# Altium schematic sheet MCU.SchDoc
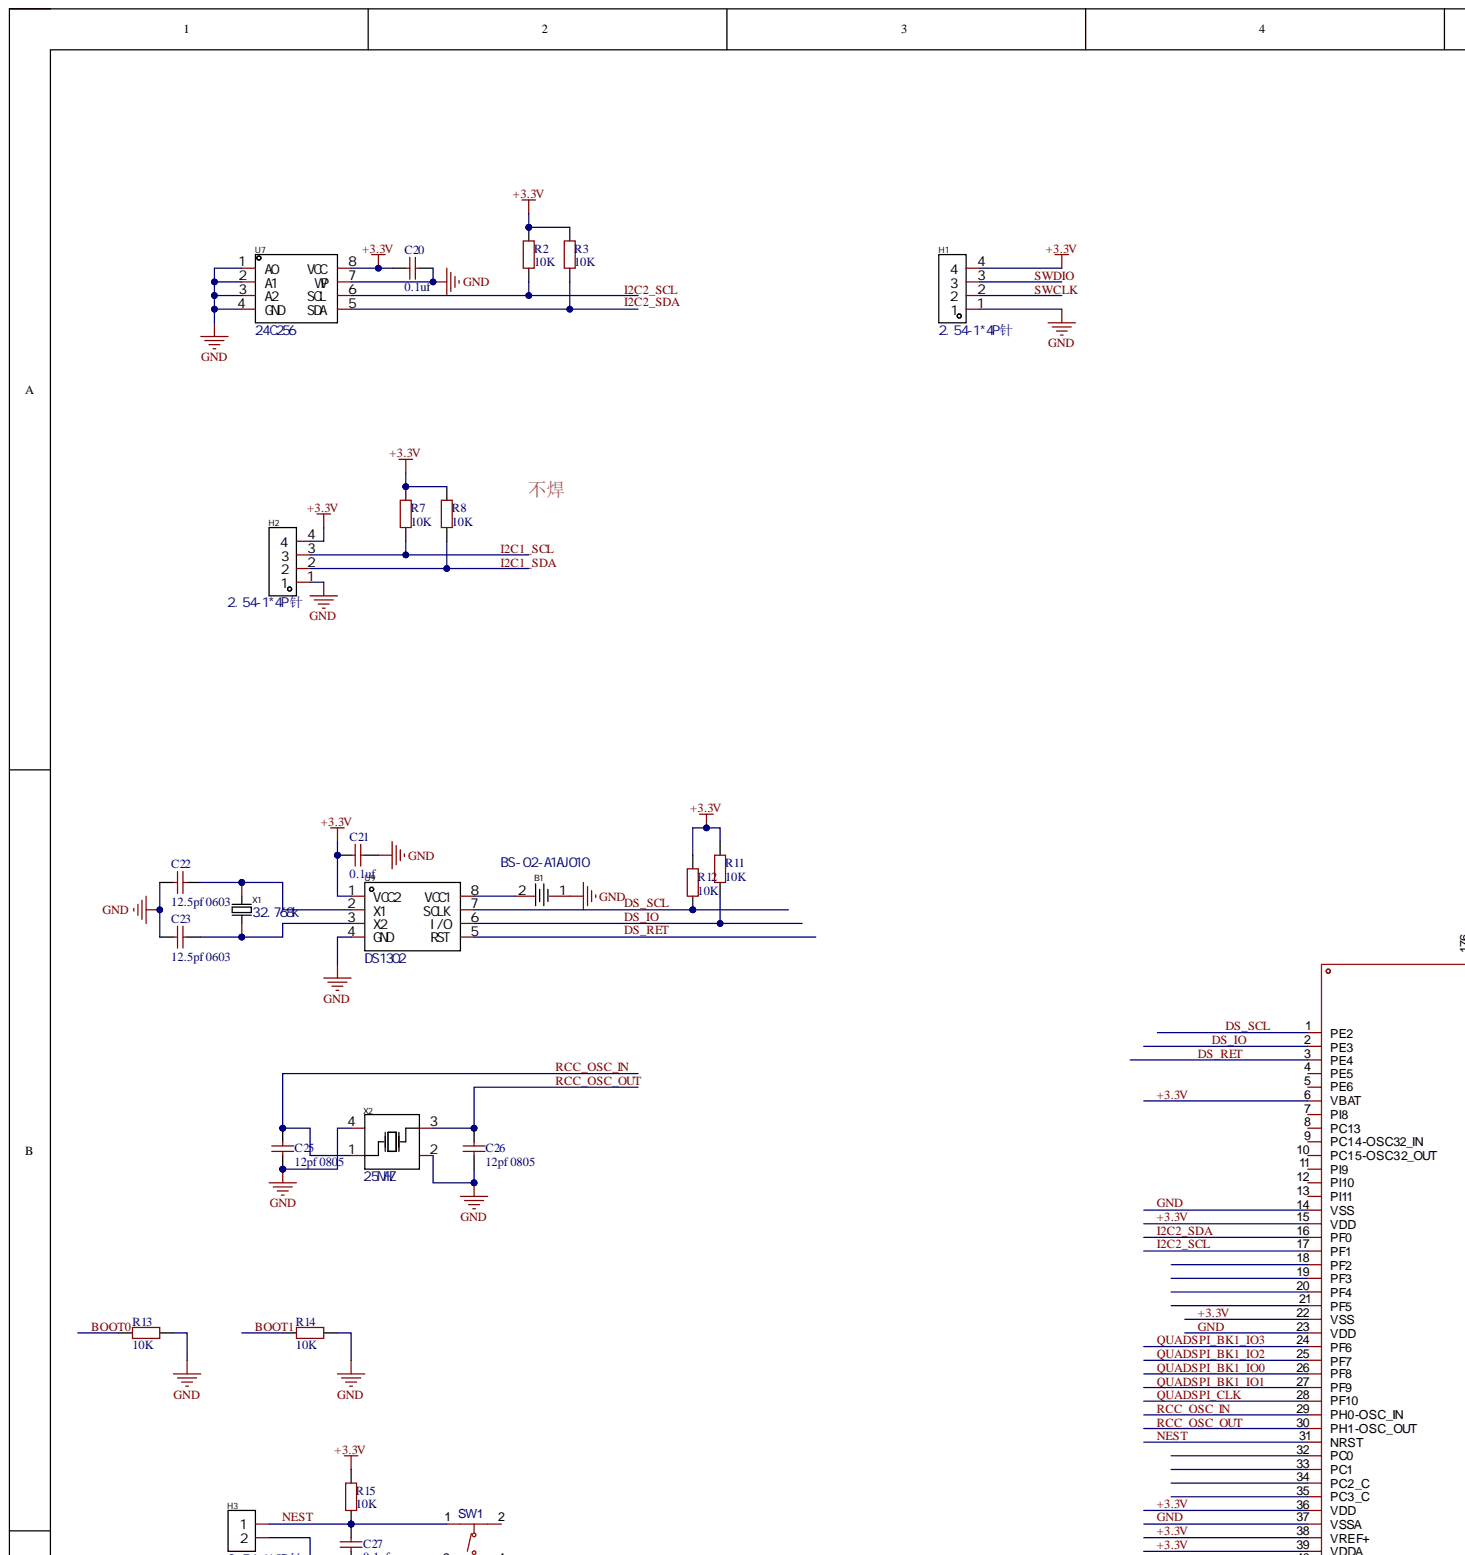
[diagram p0: MCU.SchDoc, top left]
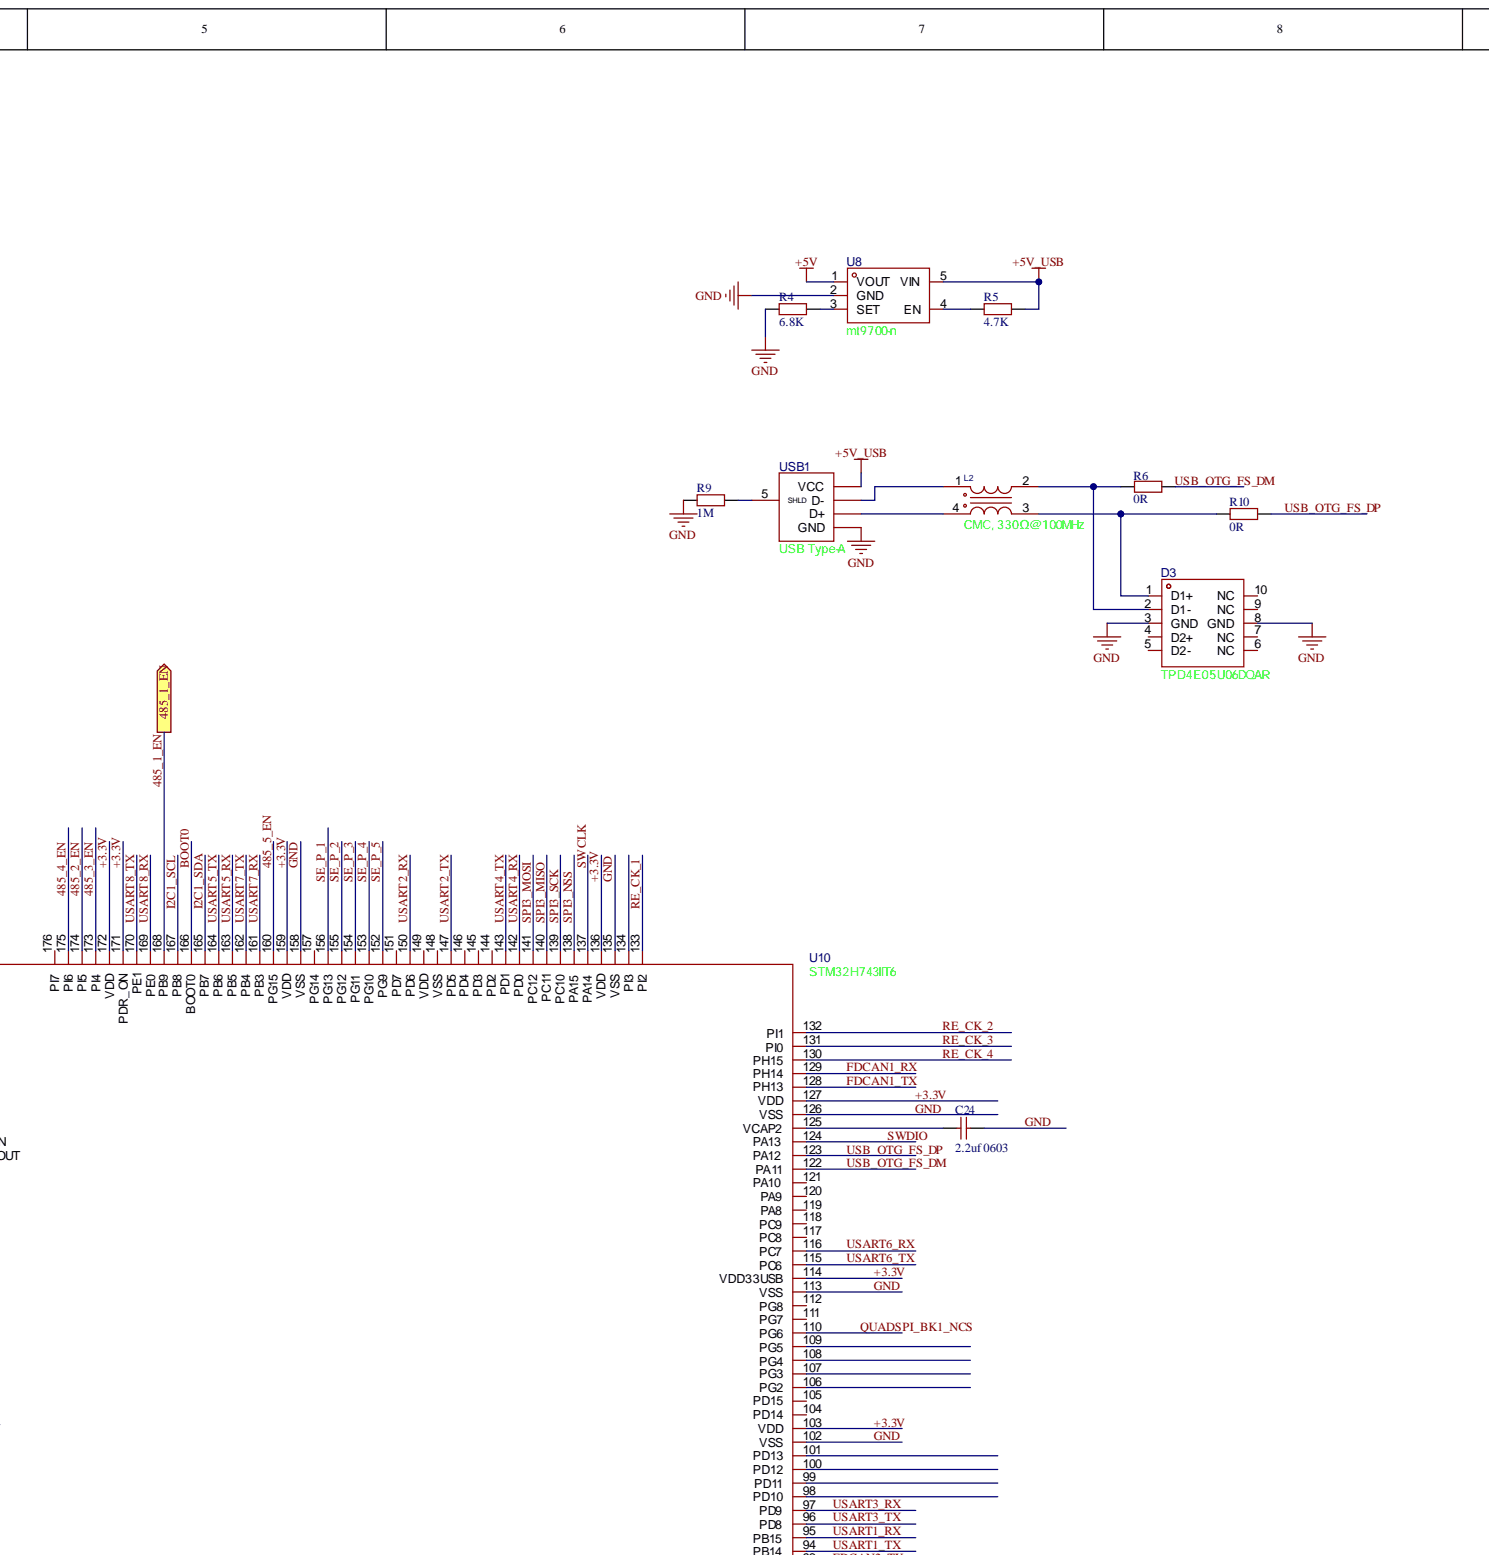
[diagram p1: MCU.SchDoc, top centre]
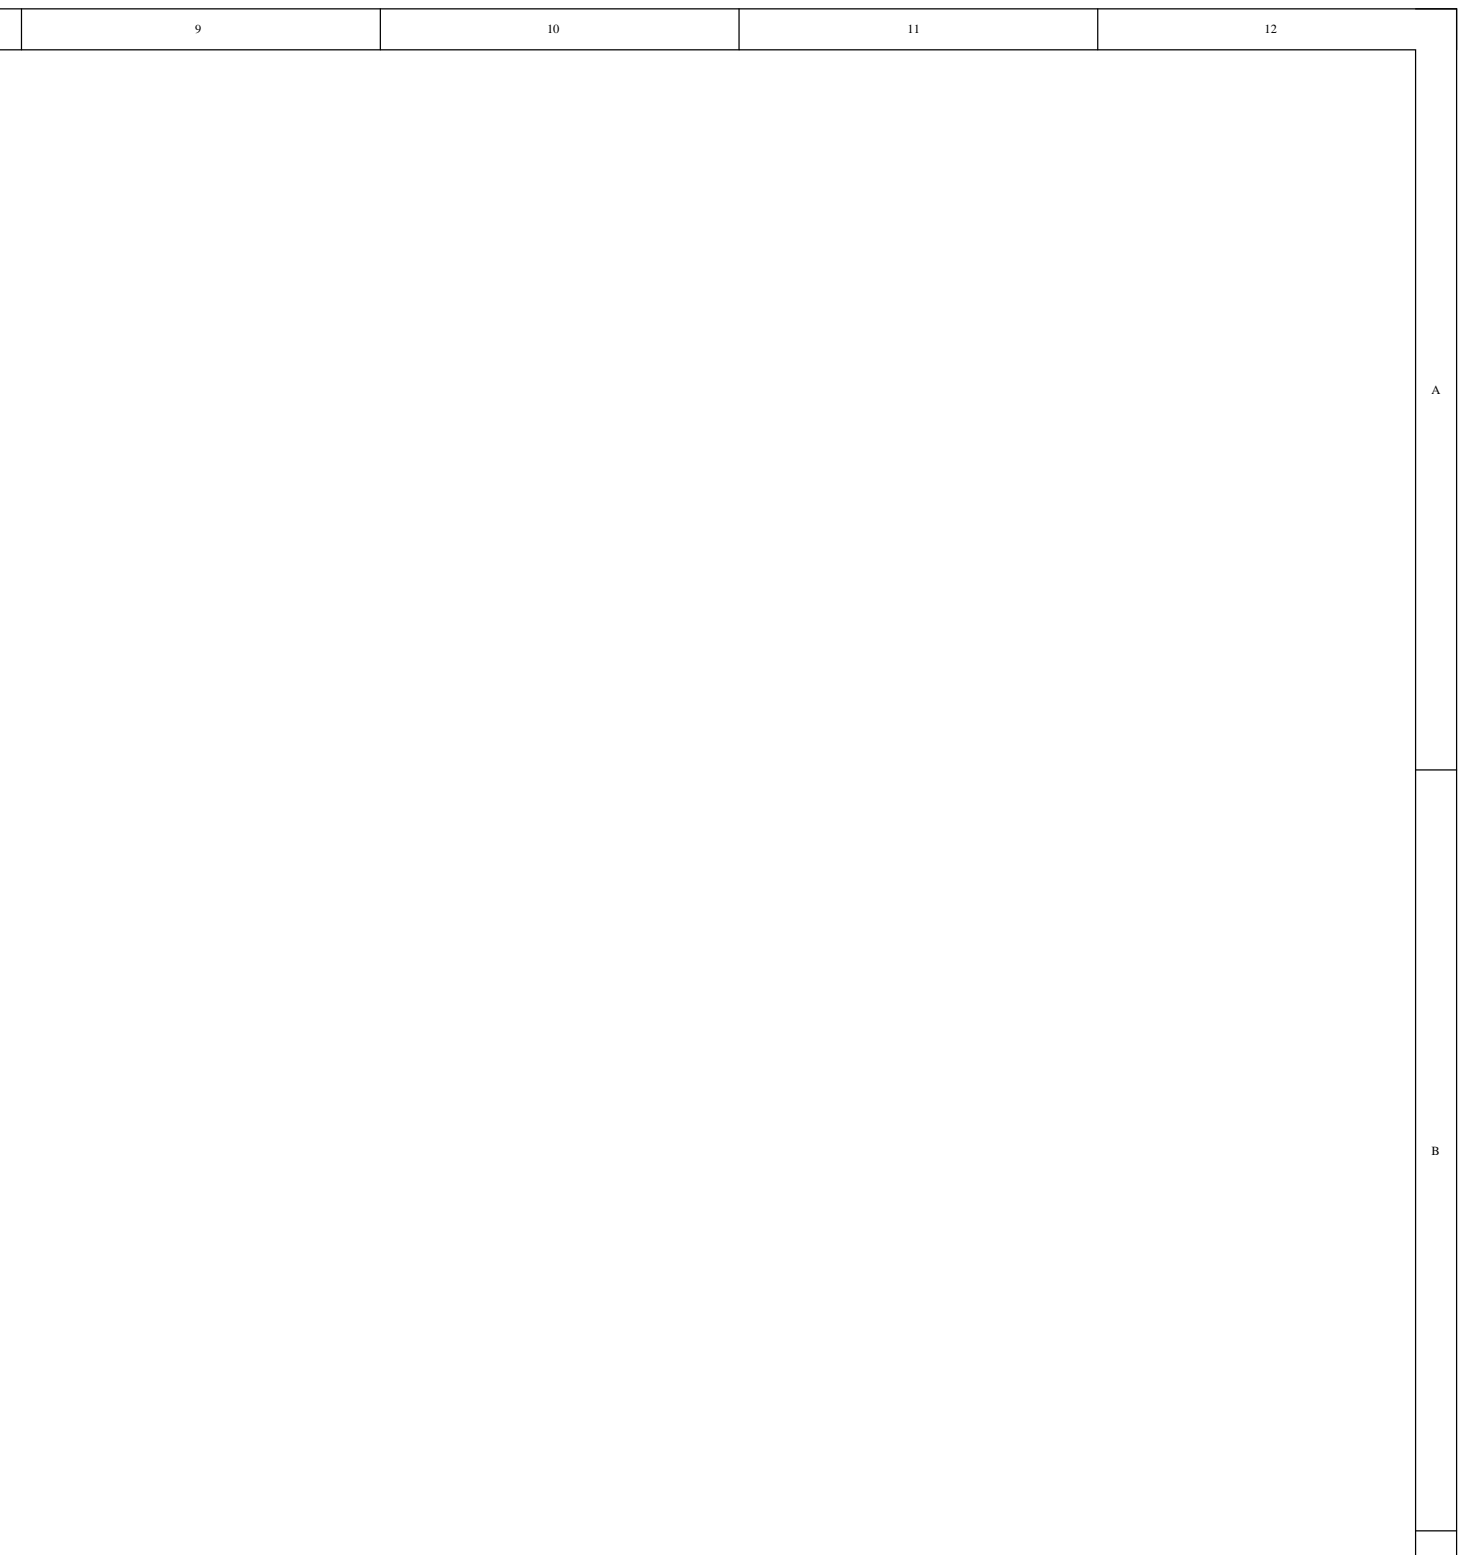
[diagram p2: MCU.SchDoc, top right]
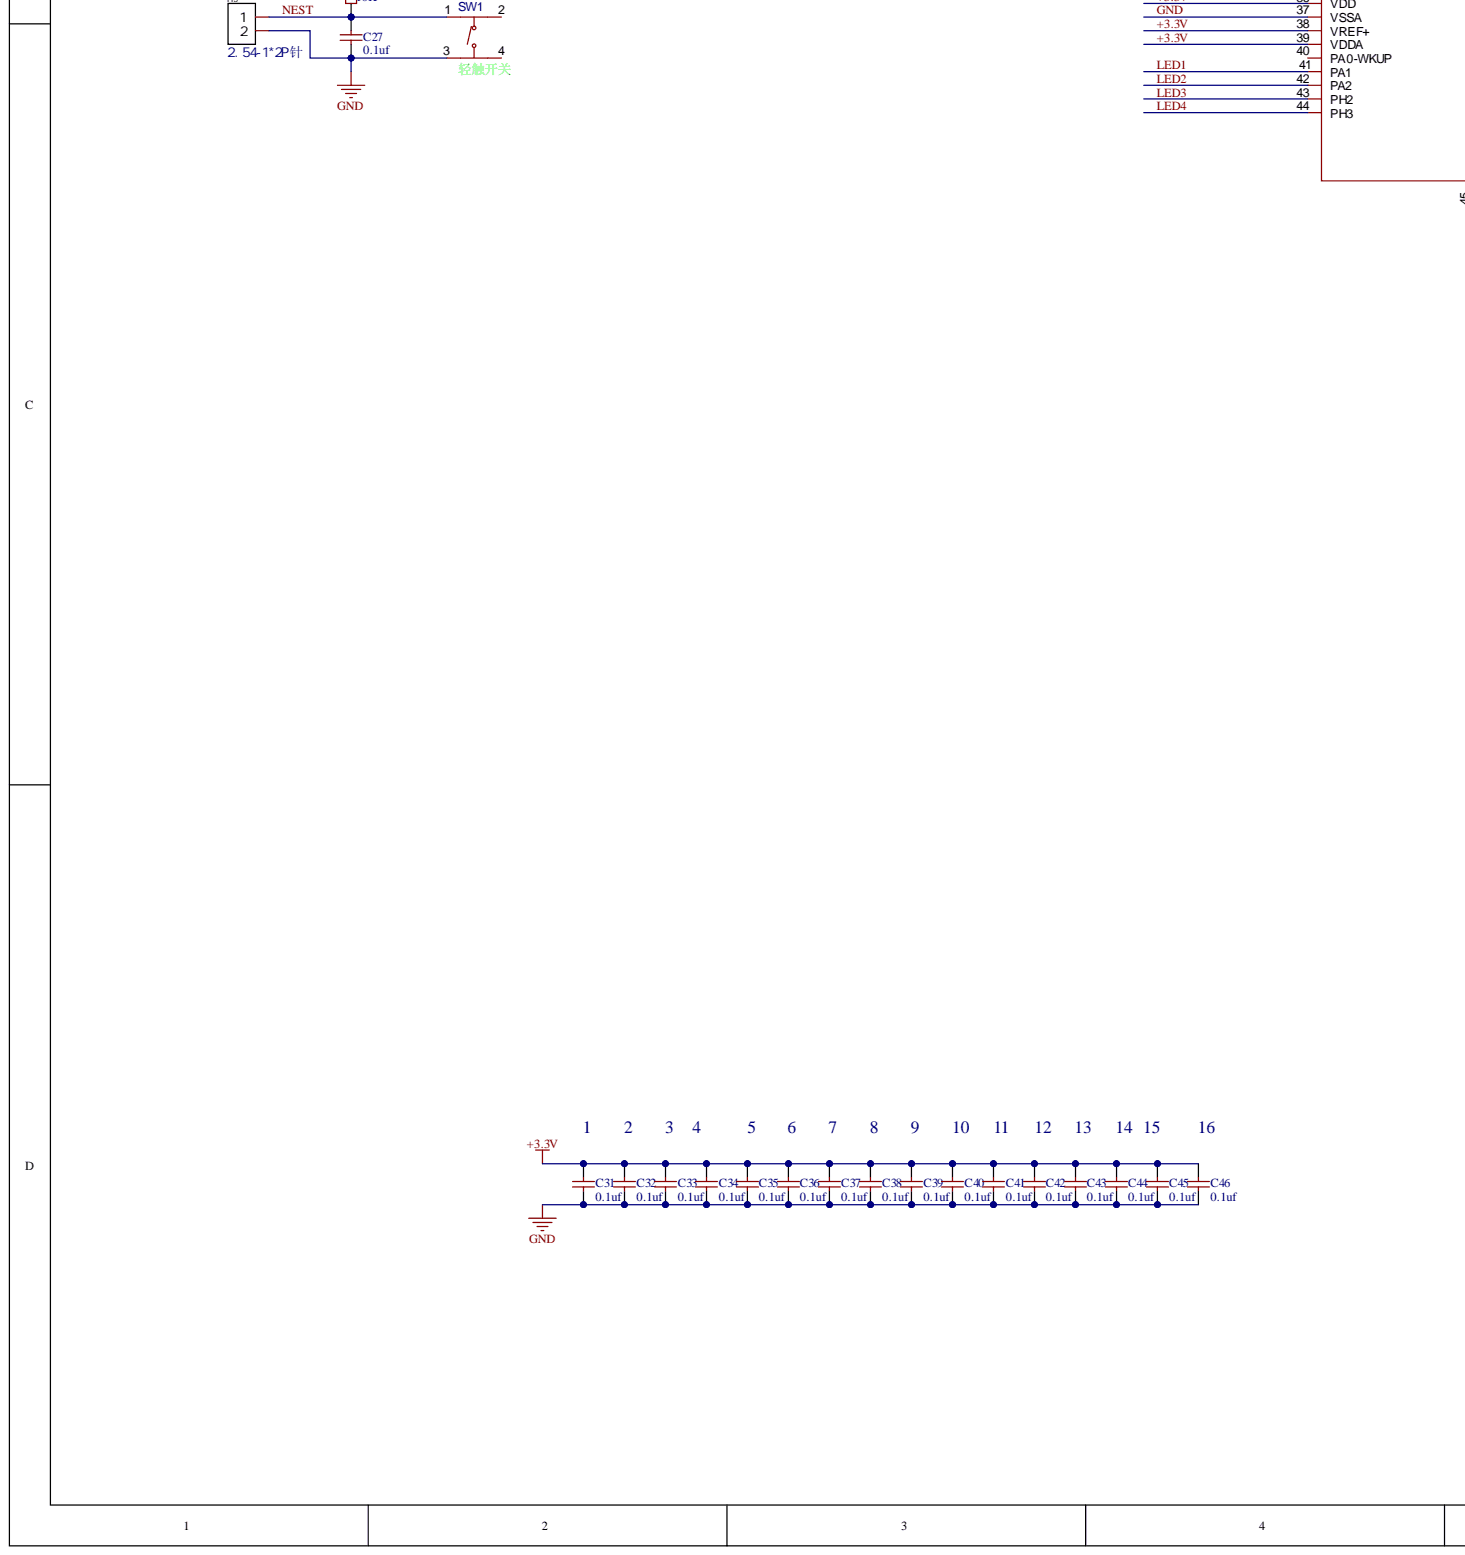
[diagram p3: MCU.SchDoc, bottom left]
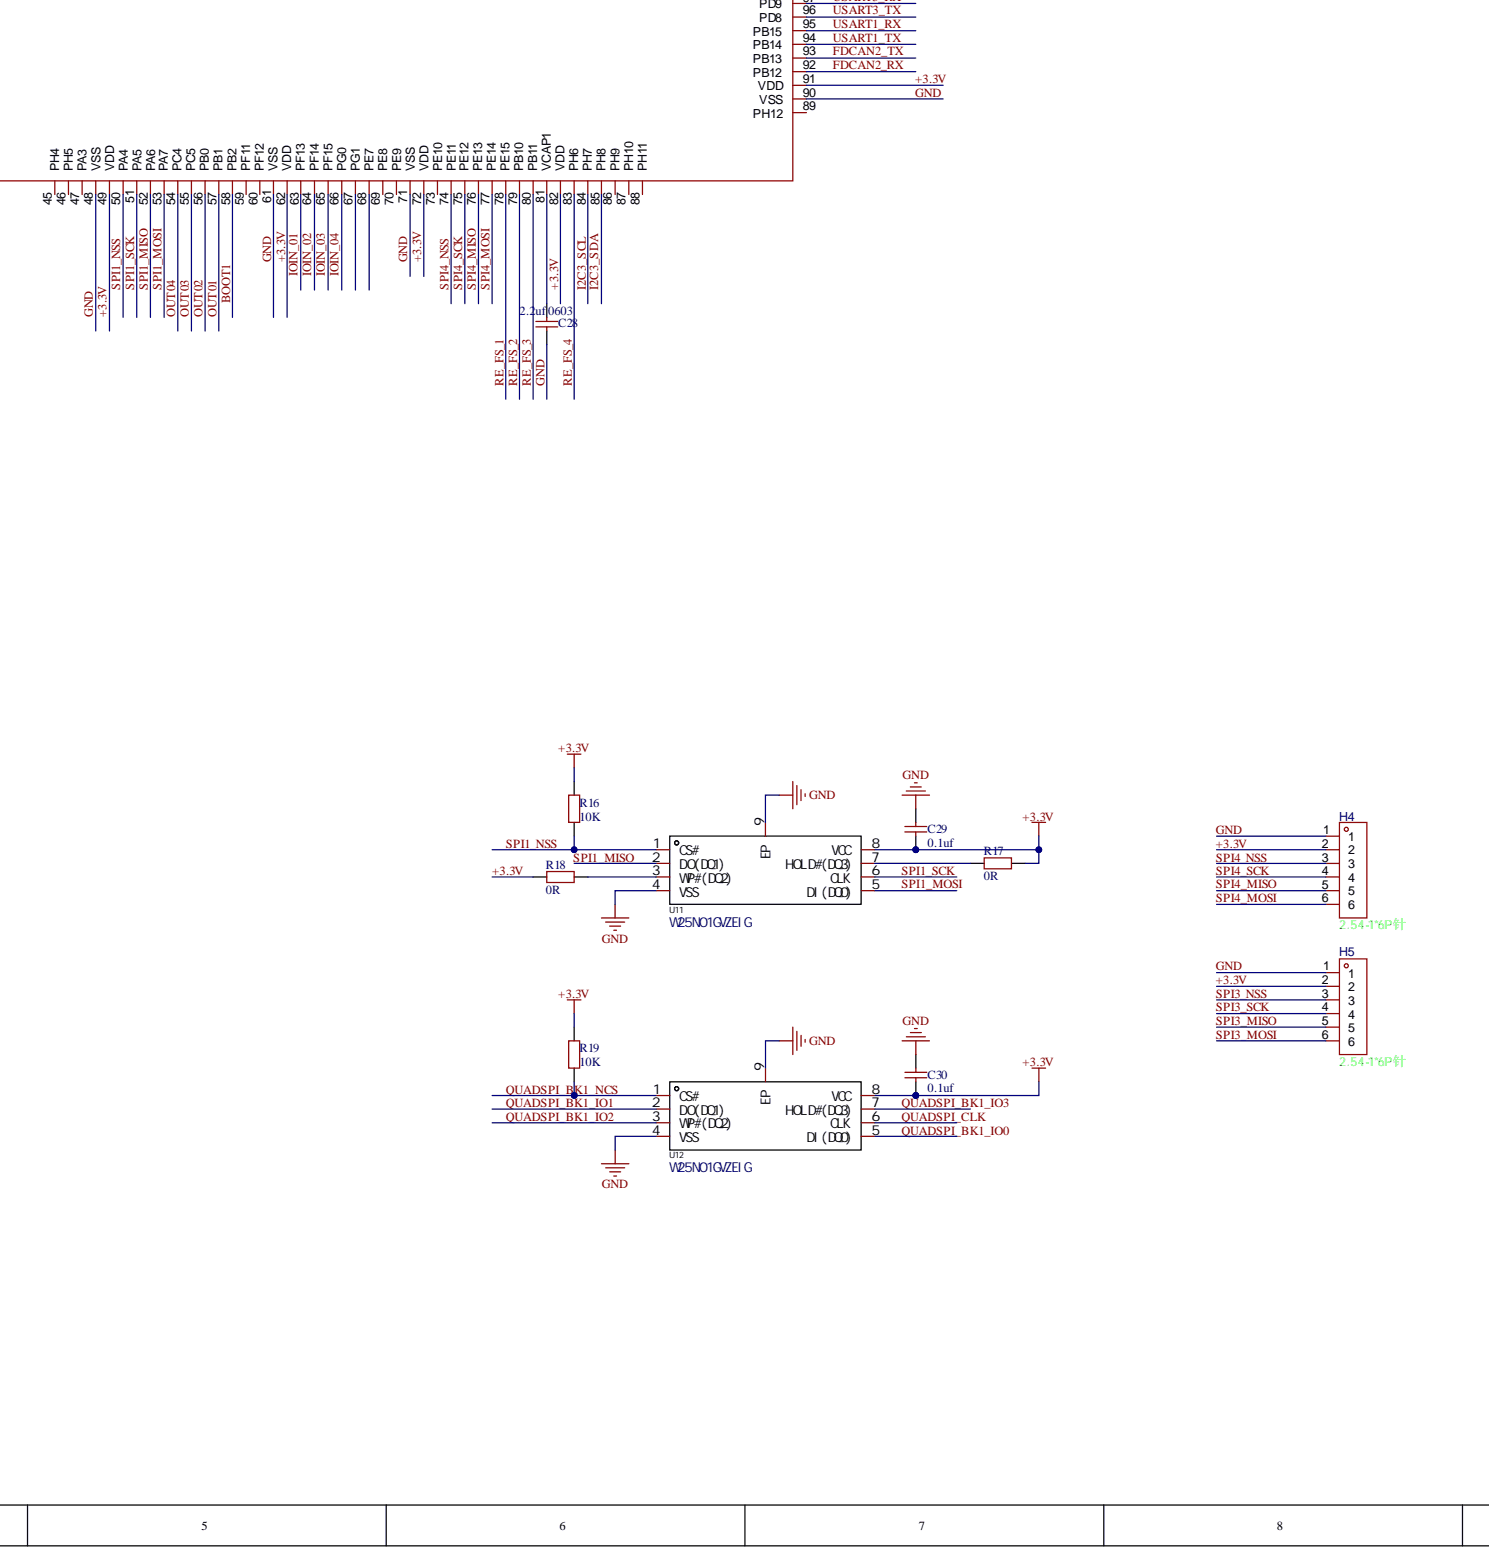
[diagram p4: MCU.SchDoc, bottom centre]
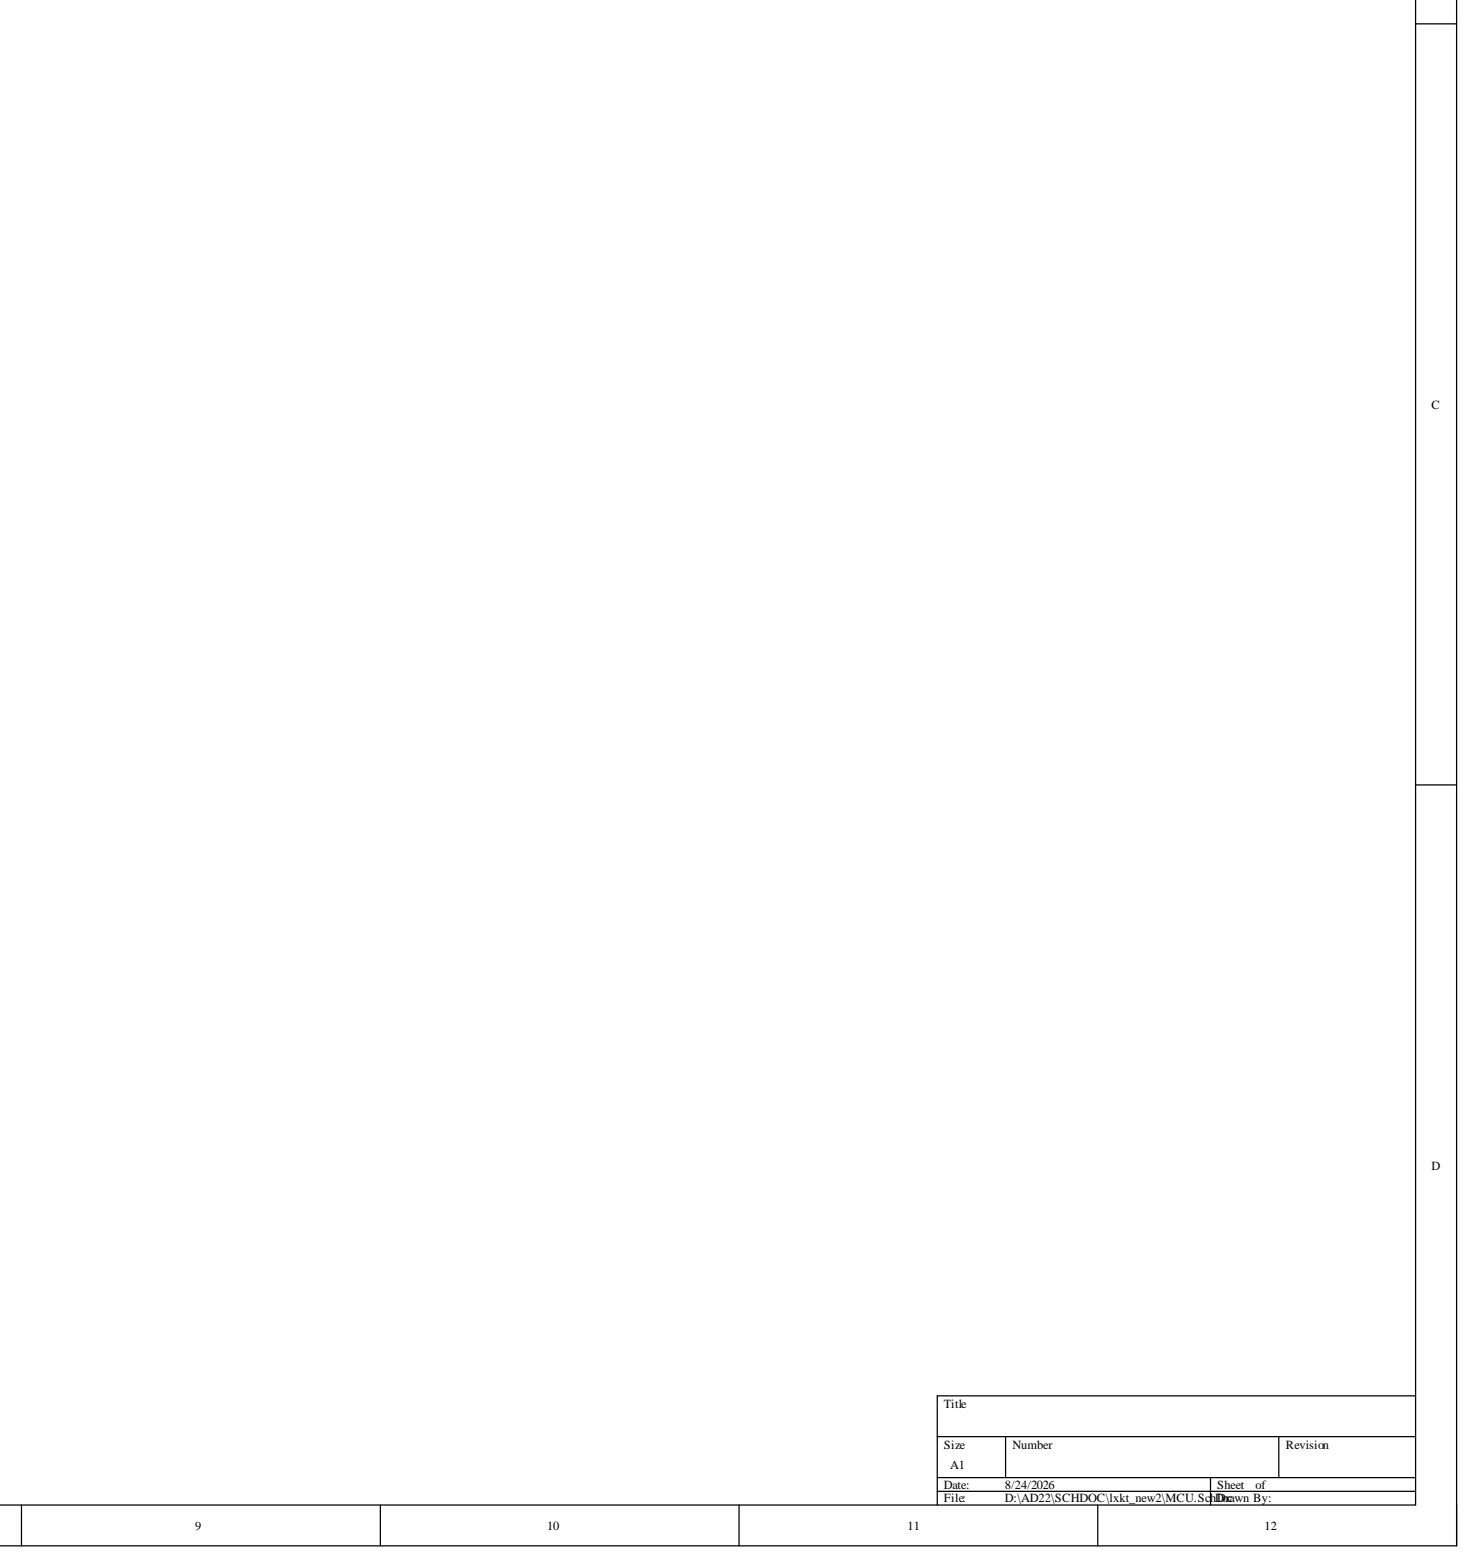
[diagram p5: MCU.SchDoc, bottom right]

## Parts
B1  BS-02-A1AJ010  [BAT-TH_BS-02-A1AJ010]
C20  0.1uf  [0805_C]
C21  0.1uf  [0805_C]
C22  12.5pf 0603  Package: 0603  [0805_C]
C23  12.5pf 0603  Package: 0603  [0805_C]
C24  2.2uf 0603  Package: 0603  [0805_C]
C25  12pf 0805  [0805_C]
C26  12pf 0805  [0805_C]
C27  0.1uf  [0805_C]
C28  2.2uf 0603  Package: 0603  [0805_C]
C29  0.1uf  [0805_C]
C30  0.1uf  [0805_C]
C31  0.1uf  [0805_C]
C32  0.1uf  [0805_C]
C33  0.1uf  [0805_C]
C34  0.1uf  [0805_C]
C35  0.1uf  [0805_C]
C36  0.1uf  [0805_C]
C37  0.1uf  [0805_C]
C38  0.1uf  [0805_C]
C39  0.1uf  [0805_C]
C40  0.1uf  [0805_C]
C41  0.1uf  [0805_C]
C42  0.1uf  [0805_C]
C43  0.1uf  [0805_C]
C44  0.1uf  [0805_C]
C45  0.1uf  [0805_C]
C46  0.1uf  [0805_C]
D3  TPD4E05U06DQAR  [USON-10_L2.5-W1.0-P0.50-BL]
H1  2.54-1*4P针  [HDR-TH_4P-P2.54-V-M]
H2  2.54-1*4P针  [HDR-TH_4P-P2.54-V-M]
H3  2.54-1*2P针  [HDR-TH_2P-P2.54-V-M]
H4  2.54-1*6P针  [HDR-TH_6P-P2.54-V-M]
H5  2.54-1*6P针  [HDR-TH_6P-P2.54-V-M]
L2  CMC, 330Ω@100MHz  Impedance @ Frequency: 330Ω@100MHz  LCSC Part Name: 2 330Ω@100MHz  [FILTER-SMD_4P-L3.2-W1.6-BL_APCW3216]
R2  10K  [0805_R]
R3  10K  [0805_R]
R4  6.8K  [0805_R]
R5  4.7K  [0805_R]
R6  0R  [0805_R]
R7  10K  [0805_R]
R8  10K  [0805_R]
R9  1M  [0805_R]
R10  0R  [0805_R]
R11  10K  [0805_R]
R12  10K  [0805_R]
R13  10K  [0805_R]
R14  10K  [0805_R]
R15  10K  [0805_R]
R16  10K  [0805_R]
R17  0R  [0805_R]
R18  0R  [0805_R]
R19  10K  [0805_R]
SW1  轻触开关  [SW-SMD_4P-L5.1-W5.1-P3.70-LS6.5-TL_H1.5]
U7  24C256  [SOIC-8_L5.0-W4.0-P1.27-LS6.0-BL]
U8  mt9700-n  [SOT-23-5_L2.9-W1.6-P0.95-LS2.9-BL]
U9  DS1302  [SOP-8_L4.9-W3.9-P1.27-LS6.0-BL]
U10  STM32H743IIT6  [LQFP-176_L24.0-W24.0-P0.50-LS26.0-BL]
U11  W25N01GVZEIG  [WSON-8_L8.0-W6.10-P1.27-BL-EP]
U12  W25N01GVZEIG  [WSON-8_L8.0-W6.10-P1.27-BL-EP]
USB1  USB Type-A  Connector Type: Type-A  [USB-A-TH_AF-WJDG]
X1  32.768k  [OSC-TH_BD3.1-P0.8-D0.3]
X2  25MHZ  [SMD3225-4P]

## Ports
485_1_EN

## Nets
+5V: U8.1(VOUT)
+5V_USB: R5.1 U8.5(VIN) USB1.1(VCC)
485_1_EN: U10.168(PB9) ; port 485_1_EN
485_2_EN: U10.174(PI5)
485_3_EN: U10.173(PI4)
485_4_EN: U10.175(PI6)
485_5_EN: U10.160(PG15)
+3.3V: C20.2 C21.2 C29.1 C30.1 C31.2 C32.2 C33.2 C34.2 C35.2 C36.2 C37.2 C38.2 C39.2 C40.2 C41.2 C42.2 C43.2 C44.2 C45.2 C46.2 H1.4 H2.4 H4.2 H5.2 R2.2 R3.2 R7.2 R8.2 R11.2 R12.2 R15.2 R16.2 R17.1 R18.2 R19.2 U7.8(VCC) U9.1(VCC2) U10.6(VBAT) U10.15(VDD) U10.22(VSS) U10.36(VDD) U10.38(VREF+) U10.39(VDDA) U10.49 U10.62(VDD) U10.72(VDD) U10.82(VDD) U10.91(VDD) U10.103(VDD) U10.114(VDD33USB) U10.127(VDD) U10.136(VDD) U10.159(VDD) U10.171(PDR_ON) U10.172 U11.8(VCC) U12.8(VCC)
BOOT0: R13.1 U10.166(BOOT0)
BOOT1: R14.1 U10.58(PB2)
DS_IO: R11.1 U9.6(I/O) U10.2(PE3)
DS_RET: U9.5(RST) U10.3(PE4)
DS_SCL: R12.1 U9.7(SCLK) U10.1(PE2)
FDCAN1_RX: U10.129(PH14)
FDCAN1_TX: U10.128(PH13)
FDCAN2_RX: U10.92(PB12)
FDCAN2_TX: U10.93(PB13)
GND: B1.1 C20.1 C21.1 C22.1 C23.1 C24.1 C25.1 C26.1 C27.1 C28.1 C29.2 C30.2 C31.1 C32.1 C33.1 C34.1 C35.1 C36.1 C37.1 C38.1 C39.1 C40.1 C41.1 C42.1 C43.1 C44.1 C45.1 C46.1 D3.3(GND) D3.8(GND) H1.1 H2.1 H3.2 H4.1 H5.1 R4.2 R9.2 R13.2 R14.2 SW1.3 U7.1(A0) U7.2(A1) U7.3(A2) U7.4(GND) U7.7(WP) U8.2(GND) U9.4(GND) U10.14(VSS) U10.23(VDD) U10.37(VSSA) U10.48(VSS) U10.61(VSS) U10.71(VSS) U10.90(VSS) U10.102(VSS) U10.113(VSS) U10.126(VSS) U10.135(VSS) U10.158(VSS) U11.4(VSS) U11.9(EP) U12.4(VSS) U12.9(EP) USB1.4(GND) X2.2 X2.4
I2C1_SCL: H2.3 R7.1 U10.167(PB8)
I2C1_SDA: H2.2 R8.1 U10.165(PB7)
I2C2_SCL: R2.1 U7.6(SCL) U10.17(PF1)
I2C2_SDA: R3.1 U7.5(SDA) U10.16(PF0)
I2C3_SCL: U10.84(PH7)
I2C3_SDA: U10.85(PH8)
IOIN_01: U10.63(PF13)
IOIN_02: U10.64(PF14)
IOIN_03: U10.65
IOIN_04: U10.66(PG0)
LED1: U10.41(PA1)
LED2: U10.42(PA2)
LED3: U10.43(PH2)
LED4: U10.44(PH3)
NEST: C27.2 H3.1 R15.1 SW1.1 U10.31(NRST)
OUT01: U10.57(PB1)
OUT02: U10.56(PB0)
OUT03: U10.55(PC5)
OUT04: U10.54(PC4)
QUADSPI_BK1_IO0: U10.26(PF8) U12.5(DI(DQ0))
QUADSPI_BK1_IO1: U10.27(PF9) U12.2(DO(DQ1))
QUADSPI_BK1_IO2: U10.25(PF7) U12.3(WP#(DQ2))
QUADSPI_BK1_IO3: U10.24(PF6) U12.7(HOLD#(DQ3))
QUADSPI_BK1_NCS: R19.1 U10.110(PG6) U12.1(CS#)
QUADSPI_CLK: U10.28(PF10) U12.6(CLK)
RCC_OSC_IN: C25.2 U10.29(PH0-OSC_IN) X2.1
RCC_OSC_OUT: C26.2 U10.30(PH1-OSC_OUT) X2.3
RE_CK_1: U10.133(PI2)
RE_CK_2: U10.132(PI1)
RE_CK_3: U10.131(PI0)
RE_CK_4: U10.130(PH15)
RE_FS_1: U10.78(PE15)
RE_FS_2: U10.79(PB10)
RE_FS_3: U10.80(PB11)
RE_FS_4: U10.83(PH6)
SE_P_1: U10.156
SE_P_2: U10.155(PG12)
SE_P_3: U10.154(PG11)
SE_P_4: U10.153(PG10)
SE_P_5: U10.152(PG9)
SPI1_MISO: U10.52(PA6) U11.2(DO(DQ1))
SPI1_MOSI: U10.53(PA7) U11.5(DI(DQ0))
SPI1_NSS: R16.1 U10.50(PA4) U11.1(CS#)
SPI1_SCK: U10.51(PA5) U11.6(CLK)
SPI3_MISO: H5.5 U10.140(PC11)
SPI3_MOSI: H5.6 U10.141(PC12)
SPI3_NSS: H5.3 U10.138(PA15)
SPI3_SCK: H5.4 U10.139(PC10)
SPI4_MISO: H4.5 U10.76(PE13)
SPI4_MOSI: H4.6 U10.77(PE14)
SPI4_NSS: H4.3 U10.74(PE11)
SPI4_SCK: H4.4 U10.75(PE12)
SWCLK: H1.2 U10.137(PA14)
SWDIO: H1.3 U10.124(PA13)
USART1_RX: U10.95(PB15)
USART1_TX: U10.94(PB14)
USART2_RX: U10.150(PD6)
USART2_TX: U10.147(PD5)
USART3_RX: U10.97(PD9)
USART3_TX: U10.96(PD8)
USART4_RX: U10.142(PD0)
USART4_TX: U10.143(PD1)
USART5_RX: U10.163(PB5)
USART5_TX: U10.164(PB6)
USART6_RX: U10.116(PC7)
USART6_TX: U10.115(PC6)
USART7_RX: U10.161(PB3)
USART7_TX: U10.162(PB4)
USART8_RX: U10.169(PE0)
USART8_TX: U10.170(PE1)
USB_OTG_FS_DM: R6.1 U10.122(PA11)
USB_OTG_FS_DP: R10.1 U10.123(PA12)
NetB1_2: B1.2 U9.8(VCC1)
NetC22_2: C22.2 U9.2(X1) X1.1
NetC23_2: C23.2 U9.3(X2) X1.2
NetC24_2: C24.2 U10.125(VCAP2)
NetC28_2: C28.2 U10.81(VCAP1)
NetD3_1: D3.1(D1+) L2.3 R10.2
NetD3_2: D3.2(D1-) L2.2 R6.2
NetL2_1: L2.1 USB1.2(D-)
NetL2_4: L2.4 USB1.3(D+)
NetR4_1: R4.1 U8.3(SET)
NetR5_2: R5.2 U8.4(EN)
NetR9_1: R9.1 USB1.5(SHLD)
NetR17_2: R17.2 U11.7(HOLD#(DQ3))
NetR18_1: R18.1 U11.3(WP#(DQ2))

Not connected: D3 4(D2+) 5(D2-) 6(NC) 7(NC) 9(NC) 10(NC); SW1 2 4; U10 4(PE5) 5(PE6) 7(PI8) 8(PC13) 9(PC14-OSC32_IN) 10(PC15-OSC32_OUT) 11(PI9) 12(PI10) 13(PI11) 18(PF2) 19(PF3) 20(PF4) 21(PF5) 32(PC0) 33(PC1) 34(PC2_C) 35(PC3_C) 40(PA0-WKUP) 45(PH4) 46(PH5) 47(PA3) 59(PF11) 60(PF12) 67(PG1) 68(PE7) 69(PE8) 70(PE9) 73(PE10) 86(PH9) 87(PH10) 88(PH11) 89(PH12) 98(PD10) 99(PD11) 100(PD12) 101(PD13) 104(PD14) 105(PD15) 106(PG2) 107(PG3) 108(PG4) 109(PG5) 111(PG7) 112(PG8) 117(PC8) 118(PC9) 119(PA8) 120(PA9) 121(PA10) 134(PI3) 144(PD2) 145(PD3) 146(PD4) 148(VSS) 149(VDD) 151(PD7) 157(PG14) 176(PI7)

## Library data of the parts
B1 = BS-02-A1AJ010: 电池座; Manufacturer: MYOUNG(美阳); Add into BOM: yes; Battery Type: CR2032; Connector Type: 电池底座; Component Kind: Standard; Convert to PCB: yes; Datasheet: https://atta.szlcsc.com/upload/public/pdf/source/20221102/8F00F2B23A2A3C447A59C327BBA6C743.pdf; Device: BS-02-A1AJ010; JLCPCB Part Class: Extended Part; LCSC Part Name: 电池底座 适用电池:CR2032; Manufacturer Part: BS-02-A1AJ010; Operating Temperature Range: -25℃~+85℃; Supplier: LCSC; Supplier Footprint: 插件; Supplier Part: C5239862; Symbol: BS-02-A1AJ010; Library Name: lxkt.IntLib
C20-C46 = C 0603 1.0pF (010) 0.25pF 50V: 贴片电容; Component Kind: Standard; ComponentLink1Description: 供应商链接; ComponentLink1URL: http://www.szlcsc.com/product/details_24709.html; Library Name: BasicComponents2205.IntLib; Supplier: LC; SuppliersPartNumber: C23969
  C20 C21 C25-C27 C29-C46: Package: 0603
D3 = TPD4E05U06DQAR: 极性:单向;反向截止电压(Vrwm):5.5V;钳位电压:14V;峰值脉冲电流(Ipp):2.5A@8/20us;峰值脉冲功率(Ppp):40W@8/20us;击穿电压:6.5V;; Manufacturer: TI(德州仪器); AddInBom: yes; Clamping Voltage: 14V; Junction Capacitance: 0.5pF; level of protection: IEC 61000-4-4；IEC 61000-4-5；IEC 61000-4-2; ModelId: ab22a3507c584f4790945dcc3c1a797e; ModelName: USON-10_L2.5-W1.0-H0.6-P0.50; ModelTransform: 40.1574,98.425,0,90,0,0,0.001,0,0; Number of Channels: 四路; Polarity: 单向; Reverse Leakage Current (Ir): 10nA; Reverse Stand-Off Voltage (Vrwm): 5.5V; Symbol: TPD4E05U06DQAR_C138714; Type: ESD; Voltage - Breakdown: 6.5V; Component Kind: Standard; ConvertToPcb: yes; Datasheet: https://www.ti.com/cn/lit/gpn/tpd4e05u06; FootprintName: USON-10_L2.5-W1.0-P0.50-BL; JLCPCB Part Class: Extended Part; LCSC Part Name: 单向TVS 5.5V截止 峰值脉冲电流：2.5A@8/20us; ManufacturerPart: TPD4E05U06DQAR; Operating Temperature: -40℃~+125℃; Peak Pulse Current (Ipp): 2.5A@8/20us; Peak Pulse Power Dissipation (Ppp): 40W@8/20us; Supplier: LCSC; Supplier Footprint: USON-10(1x2.5); SupplierPart: C138714; Library Name: WXcharge0210.IntLib
H1 H2 = 2.54-1*4P针: 排针; Manufacturer: XFCN(兴飞); Add into BOM: yes; Circluar Pins/Square Pins: 方针; Current Rating (Max): 3A; Device: PZ254V-11-04P; Pin Structure: 1x4P; Pitch: 2.54mm; Symbol: PZ254V-11-04P_C2691448; Voltage Rating (Max): 250V; Color: 黑色; Component Kind: Standard; Contact Material: -; Convert to PCB: yes; Datasheet: https://atta.szlcsc.com/upload/public/pdf/source/20200309/C492403_F9F19131791D08044AD4F84F8E751A34.pdf; Insulation Height: 2.5mm; JLCPCB Part Class: Extended Part; LCSC Part Name: 2.54mm 1x4P 直插 方针; Length of End Connection Pin: 3mm; Length of Mating Pin: 6mm; Manufacturer Part: PZ254V-11-04P; Mounting Type: 直插; Name: PZ254V-11-04P; Number of Pins: 4; Number of Rows: 单排; Operating Temperature Range: -40℃~+105℃; Row Spacing: -; Supplier: LCSC; Supplier Footprint: 直插,P=2.54mm; Supplier Part: C2691448; Library Name: WXcharge0210.IntLib
H3 = 2.54-1*2P针: 排针; Manufacturer: BOOMELE(博穆精密); Add into BOM: yes; Circluar Pins/Square Pins: 方针; Current Rating (Max): 3A; Device: 2.54-1*3P针; Pin Structure: 1x3P; Pitch: 2.54mm; Symbol: Header-Male-2.54_1x3; Color: 黑色; Component Kind: Standard; Contact Material: -; Contact Plating: -; Convert to PCB: yes; Datasheet: https://atta.szlcsc.com/upload/public/pdf/source/20221018/3E9408392E35E6F57A28985E5F7BF5C9.pdf; Insulation Height: -; JLCPCB Part Class: Extended Part; LCSC Part Name: 2.54mm 1x3P 直插 方针; Length of End Connection Pin: -; Length of Mating Pin: -; Manufacturer Part: 2.54-1*3P针; Mounting Type: 直插; Name: 2.54-1*3P针; Number of Pins: 3; Number of Rows: 单排; Operating Temperature Range: -40℃~+105℃; Row Spacing: -; Supplier: LCSC; Supplier Footprint: 插件,P=2.54mm; Supplier Part: C49257; Voltage Rating (Max): -; Library Name: WXcharge0210.IntLib
H4 H5 = 2.54-1*6P针: 排针; Symbol: PZ254V-11-10P; Component Kind: Standard; Library Name: WXcharge0210.IntLib
L2 = CMC, 330Ω@100MHz: 通道数:2;电感@频率:-;阻抗@频率:330Ω@100MHz;直流电阻(DCR):-;C54123800 C2926979 C41364541; Manufacturer: APV(爱普微); ManufacturerPart: APCW3216-331P2T; AddInBom: yes; Insulation Resistance(IR): 10MΩ; ModelId: b0aebaa3e6e54d54922b08140cb08b41; ModelTransform: 126.7714,63.7794,0,0,0,0,0,0,0; Number of Lines: 2; Voltage Rating - DC: 50V; Component Kind: Standard; ConvertToPcb: yes; Current Rating: -; Datasheet: https://item.szlcsc.com/datasheet/APCW3216-331P2T/57349933.html; DC Resistance(DCR): -; FootprintName: FILTER-SMD_4P-L3.2-W1.6-BL_APCW3216; Inductance @ Frequency: -; JLCPCB Part Class: Extended Part; ModelName: FILTER-SMD_4P-L3.2-W1.6-BL_APCW3216; Operating Temperature: -40℃~+125℃; Supplier: LCSC; Supplier Footprint: SMD-4P,3.2x1.6mm; SupplierPart: C54123800; SymbolName: APCW3216-331P2T; Voltage Rating (AC): -; Voltage Withstand: -; Library Name: WXcharge0210.IntLib
R2-R19 = R 0603 0Ω (0R0) 1%: 贴片电阻; Component Kind: Standard; ComponentLink1Description: 供应商链接; ComponentLink1URL: http://www.szlcsc.com/product/details_21903.html; Library Name: BasicComponents2205.IntLib; Package: 0603; Supplier: LC; SuppliersPartNumber: C21189
SW1 = TS-1187A-B-A-B: 电路结构:单刀单掷;按钮形状:圆形按钮;作用力:1.6N;安装方式:立贴;开关长度:5.1mm;开关宽度:5.1mm;开关高度:1.5mm;带支架:不带支架;触点电流:50mA;额定电压:12V;带灯:无;工作寿命:10万次;C318884; Manufacturer: XKB Connection(中国星坤); Actuator Style: 圆形按钮; Actuator/Cap Color: 金色; AddInBom: yes; Circuit: 单刀单掷; Contact Current: 50mA; Life: 10万次; ModelId: 56992f66d31b474c84a0c3bd0a81f82f; ModelTransform: 255.905,204.76337,0,0,0,0,0,0,0; Operating Force: 1.6N; Switch Height: 1.5mm; Voltage Rating: 12V; with Bracket: 不带支架; With Lamp: 无; Component Kind: Standard; ConvertToPcb: yes; Datasheet: https://item.szlcsc.com/datasheet/TS-1187A-B-A-B/299976.html; FootprintName: SW-SMD_4P-L5.1-W5.1-P3.70-LS6.5-TL_H1.5; JLCPCB Part Class: Basic Part; LCSC Part Name: 5.1*5.1*1.5mm 立贴 轻触开关; Length: 5.1mm; ManufacturerPart: TS-1187A-B-A-B; ModelName: SW-SMD_4P-L5.1-W5.1-P3.70-LS6.5-TL_H1.5; Mounting Type: 立贴; Operating Temperature: -30℃~+85℃; Supplier: LCSC; Supplier Footprint: SMD; SupplierPart: C318884; Symbol: TS-1187A-B-A-B; Width: 5.1mm; Library Name: WXcharge0210.IntLib
U7 = 24C02: EEPROM; Manufacturer: HGSEMI(华冠); Add into BOM: yes; Device: 24C02/TR; Component Kind: Standard; Convert to PCB: yes; Datasheet: https://atta.szlcsc.com/upload/public/pdf/source/20181022/C316692_1A8AF8718EBD0B12F50671BDFB0B8E66.pdf; JLCPCB Part Class: Extended Part; LCSC Part Name: 24C02/TR; Manufacturer Part: 24C02/TR; Name: 24C02/TR; Supplier: LCSC; Supplier Footprint: SOP-8; Supplier Part: C316692; Symbol: 24C02/TR; Library Name: WXcharge0210.IntLib
U8 = MT9700-N: USB电源,类型:-;通道数:1;输入控制逻辑:高电平有效;工作电压:2.4V~5.5V;最大连续电流:2A;导通电阻:80mΩ;; Manufacturer: 西安航天民芯; AddInBom: yes; Control Input Logic: 高电平有效; Maximum Continuous Current: 2A; ModelId: f208335ea6894ff1b04bfab971ca745a; ModelTransform: 114.173,114.173,0,0,0,0,0,-0.003,0; Number of Channels: 1; RDS(on): 80mΩ; Component Kind: Standard; ConvertToPcb: yes; Datasheet: https://item.szlcsc.com/datasheet/MT9700-N/44491709.html; Features: 短路保护；反向电流阻断；过温保护；过流保护；欠压锁定; FootprintName: SOT-23-5_L2.9-W1.6-P0.95-LS2.9-BL; JLCPCB Part Class: Extended Part; LCSC Part Name: 可调快速响应、限流型电源分配开关; ManufacturerPart: MT9700-N; ModelName: SOT-23-5_L2.9-W1.6-P0.95-LS2.9-BL; Operating Temperature	-: -40℃~+85℃; Supplier: LCSC; Supplier Footprint: SOT-23-5; SupplierPart: C42441843; Symbol: MT9700-N; Type: -; Voltage - Supply: 2.4V~5.5V; Library Name: WXcharge0210.IntLib
U9 = DS1302: RTC; Manufacturer: HGSEMI(华冠); Add into BOM: yes; Device: DS1302ZM/TR; Symbol: DS1302ZM; Component Kind: Standard; Convert to PCB: yes; Datasheet: https://atta.szlcsc.com/upload/public/pdf/source/20201111/C910859_921CCC6706070F058354C83BA711E7C3.pdf; JLCPCB Part Class: Extended Part; LCSC Part Name: DS1302ZM/TR; Manufacturer Part: DS1302ZM/TR; Supplier: LCSC; Supplier Footprint: SOP-8; Supplier Part: C910859; Library Name: lxkt.IntLib
U10 = STM32H743IIT6: MCU; Component Kind: Standard; Symbol: STM32H743IIT6; Library Name: lxkt.IntLib
U11 U12 = W25N01GVZEIG: FLASH; Manufacturer: WINBOND(华邦); Add into BOM: yes; Symbol: W25N01GVZEIG_C907680; Component Kind: Standard; Convert to PCB: yes; Datasheet: https://atta.szlcsc.com/upload/public/pdf/source/20201021/C907645_0A29D2C383E097D6F46BF0FEEFAA9DEB.pdf; Device: W25N01GVZEIG; JLCPCB Part Class: Extended Part; LCSC Part Name: W25N01GVZEIG; Manufacturer Part: W25N01GVZEIG; Supplier: LCSC; Supplier Footprint: WSON-8-EP(6.1x8); Supplier Part: C907680; Library Name: lxkt.IntLib
USB1 = USB Type-A: 连接器类型:Type-A;协议标准:USB 2.0;公母:母;安装方式:弯插;触点数量:4P;端口数量:1;额定电流 - 电源:1.5A;工作温度:-25℃~+85℃;; Manufacturer: SHOU HAN(首韩); ManufacturerPart: AF 90° WJDG; AddInBom: yes; Connect-Disconnect Life: 5千次; Current Rating-Power: 1.5A; Detection color: 白色; Gender: 母; ModelId: 268f7f19b8674295a50f5e7a4a3dee80; ModelName: USB-A-TH_4P-L14.5-W14.0-H7.6-S2.71; ModelTransform: 610.19406,551.18,0,0,0,0,0,-192.915,-149.606; Number of Contacts: 4P; Number of Ports: 1; Protocol Standard: USB 2.0; Voltage Rating: 30V; Center Height: -; Component Kind: Standard; ConvertToPcb: yes; Datasheet: https://item.szlcsc.com/datasheet/AF%252090%25C2%25B0%2520WJDG/458825.html; FootprintName: USB-A-TH_AF-WJDG; JLCPCB Part Class: Extended Part; LCSC Part Name: Type-A 母 弯插; Length: 14mm; Mounting Type: 弯插; Operating Temperature: -25℃~+85℃; Supplementary Features: -; Supplier: LCSC; Supplier Footprint: 弯插; SupplierPart: C456018; Symbol: AF 90° WJDG; Library Name: WXcharge0210.IntLib
X1 = SC-32S32.768kHz20PPM12.5pF: 无源晶振C97606; Manufacturer: Seiko(精工); Add into BOM: yes; External load capacitor: 12.5pF; Normal temperature Frequency Tolerance: ±20ppm; Component Kind: Standard; Convert to PCB: yes; Crystal Type: -; Datasheet: https://atta.szlcsc.com/upload/public/pdf/source/20170713/C97606_1499914220652816606.pdf; Device: SC-32S32.768kHz20PPM12.5pF; JLCPCB Part Class: Extended Part; LCSC Part Name: 32.768kHz ±20ppm 12.5pF; Manufacturer Part: SC-32S32.768kHz20PPM12.5pF; Operating Temperature: -; Supplier: LCSC; Supplier Footprint: SMD3215-2P; Supplier Part: C97606; Symbol: SC-32S32.768kHz20PPM12.5pF; Value: 32.768kHz; Library Name: lxkt.IntLib
X2 = X322525MOB4SI: 无源晶振C9006; Manufacturer: YXC(扬兴晶振); Add into BOM: yes; Crystal Type: 贴片晶振; External load capacitor: 12pF; Frequency Stability(Full temperature range): ±20ppm; Normal temperature Frequency Tolerance: ±10ppm; Component Kind: Standard; Convert to PCB: yes; Datasheet: https://atta.szlcsc.com/upload/public/pdf/source/20240329/19D5F850207469550BEB00D7DFEDBC7A.pdf; Device: X322525MOB4SI; JLCPCB Part Class: Basic Part; LCSC Part Name: 25MHz ±10ppm 12pF; Manufacturer Part: X322525MOB4SI; Operating Temperature: -40℃~+85℃; Supplier: LCSC; Supplier Footprint: SMD3225-4P; Supplier Part: C9006; Symbol: X322525MOB4SI; Value: 25MHz; Library Name: lxkt.IntLib
